# Altium schematic sheet CAN_Hat.SchDoc(CAN_Hat)
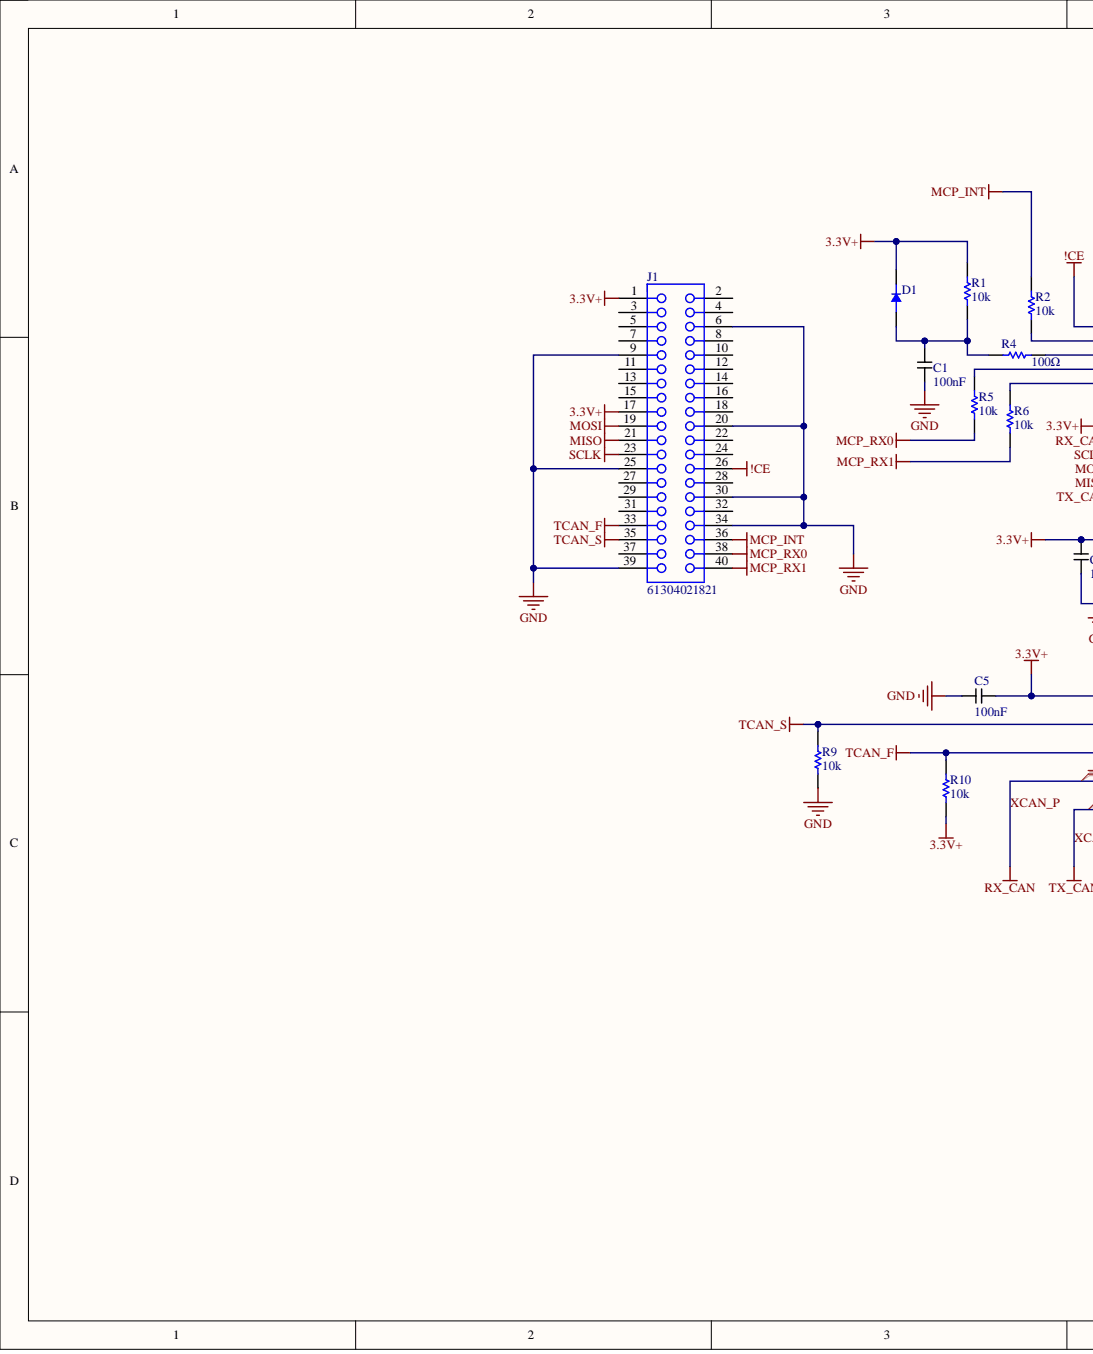
[diagram p0: CAN_Hat.SchDoc, left]
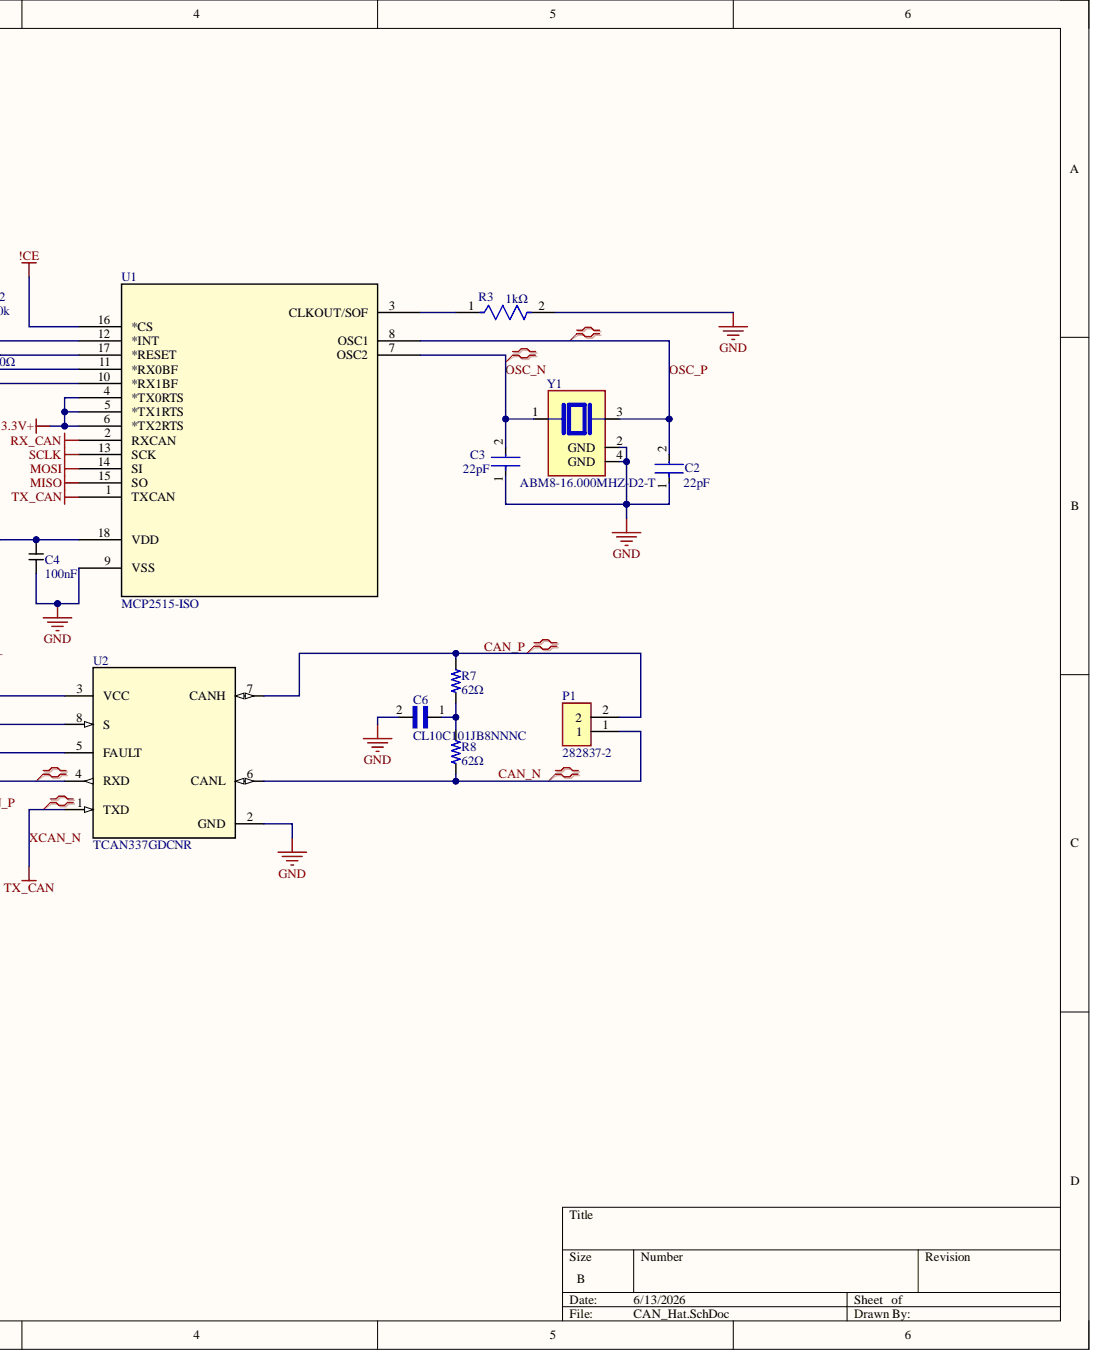
[diagram p1: CAN_Hat.SchDoc, right]

## Parts
C1  100nF
C2  value 22pF  (hidden comment: C0805C220J5GACTU)
C3  value 22pF  (hidden comment: C0805C220J5GACTU)
C4  100nF
C5  100nF
C6  CL10C101JB8NNNC
D1  1N4148W-13-F  (value not drawn)
J1  61304021821
P1  282837-2
R1  10k
R2  10k
R3  value 1kΩ  (hidden comment: ERA-3AEB102V)
R4  value 100Ω  (hidden comment: CRCW0805100RFKEA)
R5  10k
R6  10k
R7  62Ω
R8  62Ω
R9  10k
R10  10k
U1  MCP2515-ISO
U2  TCAN337GDCNR
Y1  ABM8-16.000MHZ-D2-T

## Nets
3.3V+: C4.2 C5.2 D1.2 J1.1 J1.17 R1.2 R10.1 U1.4(*TX0RTS) U1.5(*TX1RTS) U1.6(*TX2RTS) U1.18(VDD) U2.3(VCC)
!CE: J1.26 U1.16(*CS)
GND: C1.1 C2.1 C3.1 C4.1 C5.1 C6.2 J1.6 J1.9 J1.20 J1.25 J1.30 J1.34 J1.39 R3.2 R9.1 U1.9(VSS) U2.2(GND) Y1.2(GND) Y1.4(GND)
MCP_INT: J1.36 R2.1
MCP_RX0: J1.38 R5.1
MCP_RX1: J1.40 R6.1
MISO: J1.21 U1.15(SO)
MOSI: J1.19 U1.14(SI)
SCLK: J1.23 U1.13(SCK)
TCAN_F: J1.33 R10.2 U2.5(FAULT)
TCAN_S: J1.35 R9.2 U2.8(S)
CAN_N: P1.1 R8.1 U2.6(CANL)
CAN_P: P1.2 R7.2 U2.7(CANH)
OSC_N: C3.2 U1.7(OSC2) Y1.1
OSC_P: C2.2 U1.8(OSC1) Y1.3
XCAN_N: U1.1(TXCAN) U2.1(TXD)
XCAN_P: U1.2(RXCAN) U2.4(RXD)
NetC1_2: C1.2 D1.1 R1.1 R4.1
NetC6_1: C6.1 R7.1 R8.2
NetR2_2: R2.2 U1.12(*INT)
NetR3_1: R3.1 U1.3(CLKOUT/SOF)
NetR4_2: R4.2 U1.17(*RESET)
NetR5_2: R5.2 U1.11(*RX0BF)
NetR6_2: R6.2 U1.10(*RX1BF)

Not connected: J1 2 3 4 5 7 8 10 11 12 13 14 15 16 18 22 24 27 28 29 31 32 37

## Library data of the parts
C1 C4 C5 = CMP-059-000047-3: 25V 100nF X7R ±10% 0603 Multilayer Ceramic Capacitors MLCC - SMD/SMT ROHS, CC0603KRX7R8BB104; MF: Yageo; Case Code (Imperial): 0603; Case Code (Metric): 1608; Case/Package: 0603; China RoHS: Compliant; Component Kind: Standard; Component Type: Standard; Composition: Ceramic; Country of Origin: Mainland China, Taiwan; Depth: 800µm; Design Item ID: CC0603KRX7R8BB104; Dielectric: X7R; Dielectric Material: Ceramic; Export Control Classification Number (ECCN) Code: EAR99; Halogen Free: Halogen Free; Harmonized Tariff Schedule (HTS) Code: 8532.24.00.20; Height: 800µm; Introduction Date: 2004-02-24; Lead Free: Lead Free; Length: 1.6mm; Lifecycle Status: Production; Material: Ceramic; Max Operating Temperature: 125°C; Min Operating Temperature: -55°C; Mounting Technology: Surface Mount; MP: CC0603KRX7R8BB104; Number of Terminals: 2; Package: 0603 Yageo; Pin Count: 2; Pins: 2; Radiation Hardening: No; REACH SVHC: Yes; RoHS: Compliant; Schedule B: 8532240020, 8532240020; SnapEDA_Link: https://www.snapeda.com/parts/CC0603KRX7R8BB104/Yageo/view-part/?ref=snap; Source: CC0603KRX7R8BB104.SchLib; Termination: SMD/SMT; Thickness: 889µm; Tolerance: 10%; Value: 100nF; Voltage: 25V; Voltage Rating: 25V; Voltage Rating (DC): 25V; Width: 800µm; Library Name: BEV
C2 C3 = CMP-059-000096-1: SMD Multilayer Ceramic Capacitor, 22 pF, 50 V, 0805 [2012 Metric], C0G / NP0; Temperature Coefficient: 30ppm/°C; Tolerance: 5%; Voltage: 50V; Case Code (Imperial): 0805; Case/Package: 0805; China RoHS: Compliant; Component Kind: Standard; Contact Material: Tin; Contact Plating: Tin; Country of Origin: Mexico; Depth: 1.25mm; Dielectric: C0G; Dielectric Material: Ceramic; Export Control Classification Number (ECCN) Code: EAR99; Harmonized Tariff Schedule (HTS) Code: 8532.24.00.20; Height: 700µm; Introduction Date: 2008-01-10; Lead Free: Lead Free; Length: 2mm; Lifecycle Status: Production; Material: Ceramic; Max Operating Temperature: 125°C; Min Operating Temperature: -55°C; Mounting Technology: Surface Mount; Number of Terminals: 2; Packaging: Cut Tape; Pins: 2; Radiation Hardening: No; REACH SVHC: Yes; RoHS: Compliant; Schedule B: 8532240020, 8532240020; Termination: SMD/SMT; Thickness: 889µm; Voltage Rating: 50V; Voltage Rating (DC): 50V; Weight: 5.499807mg; Width: 1.25mm; Library Name: BEV
C6 = CMP-059-000039-3: 100pF Â±5% 50V Ceramic Capacitor C0G, NP0 0603 (1608 Metric); MF: Samsung; Check_prices: https://www.snapeda.com/parts/CL10C101JB8NNNC/Samsung/view-part/3597915/?ref=eda; Component Kind: Standard; Component Type: Standard; Design Item ID: CL10C101JB8NNNC; Digi-Key_Part_Number: 1276-1008-1-ND; MP: CL10C101JB8NNNC; Package: 0603; Pin Count: 2; Purchase-URL: https://pricing.snapeda.com/search/part/CL10C101JB8NNNC/?ref=eda; SnapEDA_Link: https://www.snapeda.com/parts/CL10C101JB8NNNC/Samsung/view-part/3597915/?ref=snap; Source: CL10C101JB8NNNC.SchLib; Value: 100pF; Library Name: BEV
D1 = CMP-039-000037-3: Rectifier Diode, 1 Phase, 1 Element, 0.15A, 100V V(RRM), Silicon, DO-35; Average Rectified Current: 150mA; Element Configuration: Single; Forward Current: 300mA; Forward Voltage: 715mV; Max Forward Surge Current (Ifsm): 2A; Max Junction Temperature (Tj): 150°C; Max Power Dissipation: 400mW; MF: Diodes Inc.; Number of Elements: 1; Peak Reverse Current: 1µA; Recovery Time: 4ns; Reverse Standoff Voltage: 75V; Value: 2pF; Case/Package: SOD-123-2; Check_prices: https://www.snapeda.com/parts/1N4148W-13-F/Diodes+Inc./view-part/?ref=eda; China RoHS: Compliant; Component Kind: Standard; Component Type: Standard; Design Item ID: 1N4148W-13-F; Height: 1.45mm; Lead Free: Lead Free; Length: 2.85mm; Lifecycle Status: Production; Max Operating Temperature: 150°C; Max Output current: 150mA; Max Repetitive Reverse Voltage (Vrrm): 100V; Max Reverse Voltage (DC): 100V; Max Surge Current: 2A; Min Breakdown Voltage: 100V; Min Operating Temperature: -65°C; Mounting Technology: Surface Mount; MP: 1N4148W-13-F; Number of Terminals: 2; Package: SOD-123 Fairchild Semiconductor; Peak Non-Repetitive Surge Current: 2A; Pin Count: 2; Pins: 2; Power Dissipation: 400mW; Power Rating: 400mW; Radiation Hardening: No; REACH SVHC: Yes; Reverse Recovery Time: 4ns; Reverse Voltage: 100V; RoHS: Compliant; Schedule B: 8541100070, 8541100070; SnapEDA_Link: https://www.snapeda.com/parts/1N4148W-13-F/Diodes+Inc./view-part/?ref=snap; Source: 1N4148W-13-F.SchLib; Weight: 10.007382mg; Width: 1.7mm; Library Name: BEV
J1 = CMP-133-000099-1: Header, 2.54MM Pitch, Dual Socket, Straight, 40 Pins, 3A, 500VAC, Wr-phd; Contact Resistance: 20mΩ; Gender: Female; Insulation Resistance: 1GΩ; Operating Supply Voltage: 250V; Component Kind: Standard; Contact Plating: Gold; Current Rating: 3A; Insulation Material: PBT; Lead Pitch: 2.54mm; Length: 51.3mm; Max Operating Temperature: 105°C; Min Operating Temperature: -40°C; Orientation: Vertical; Pins: 40; Pitch: 2.54mm; RoHS: Compliant; Library Name: BEV
P1 = CMP-645-000001-2: Connector, Terminal Block; Wire Receptacle; 2 Pos.; 16-30 AWG; 0.2 in. CL; Side;; Manufacturer Lifecycle Status: ACTIVE; Approvals: UL; Connector System: Wire-to-Board; Current: 13.5A; Gender: Female; Max Supply Voltage (AC): 250V; MF: TE Connectivity; Number of Circuits: 2; Number of Levels: 1; Operating Supply Voltage: 300V; Pitch: 5.08mm; Plating: Brass; Tail Length: 3.5mm; Thread Size: M3; Wire Gauge (Max): 28AWG; Wire Gauge (Min): 16AWG; Wire/Cable Gauge: 15AWG; Availability: In Stock; Check_prices: https://www.snapeda.com/parts/282837-2/TE+Connectivity+AMP+Connectors/view-part/?ref=eda; China RoHS: Non-Compliant; Color: Green; Component Kind: Standard; Component Type: Standard; Connector Type: Receptacle; Contact Material: Brass; Contact Plating: Tin; Current Rating: 13.5A; Depth: 8.2mm; Design Item ID: 282837-2; ELV: Compliant; Export Control Classification Number (ECCN) Code: EAR99; Flammability Rating: UL94 V-0; Harmonized Tariff Schedule (HTS) Code: 8536.90.40.00; Height: 10.3mm; Housing Color: Green; Housing Material: Polyamide; Introduction Date: 1997-11-01; Lead Free: Lead Free; Lifecycle Status: Production; Max Current Rating: 13.5A; Max Operating Temperature: 110°C; Max Voltage Rating (AC): 250V; Min Operating Temperature: -40°C; Mounting Technology: Screw; MP: 282837-2; Number of Contacts: 2; Number of Poles: 2; Number of Positions: 2; Number of Positions Per Level: 2; Number of Rows: 1; Orientation: Straight; Package: None; Packaging: Bulk; Pin Count: 2; Pins: 2; Price: None; Purchase-URL: https://pricing.snapeda.com/search/part/282837-2/?ref=eda; Radiation Hardening: No; REACH SVHC: No; RoHS: Compliant; SnapEDA_Link: https://www.snapeda.com/parts/282837-2/TE+Connectivity+AMP+Connectors/view-part/?ref=snap; Source: 282837-2.SchLib; Termination: Solder; Voltage: 300V; Voltage Rating: 300V; Voltage Rating (AC): 250V; Width: 11.5mm; Library Name: BEV
R1 R2 R5 R6 R9 R10 = CMP-061-000012-3: Fixed Resistor, Metal Glaze/thick Film, 0.125W, 10000ohm, 150V, 1% +/-Tol, 100ppm/Cel, Surface Mount, 0805; Power Rating: 250mW; Case/Package: 0805; Component Kind: Standard; Value: 10k; Library Name: BEV
R3 = CMP-061-000201-1: Res Thin Film 0603 1K Ohm 0.1% 0.1W ±25ppm/°C Molded SMD Punched Carrier T/R; Manufacturer Lifecycle Status: ACTIVE; Part Series: Thin Film Chip Resistors, High Reliability Type; Max Power Dissipation: 100mW; Voltage Rating: 75V; Case Code (Imperial): 0603; Case/Package: 0603; Component Kind: Standard; Composition: Thin Film; Contact Plating: Tin; Depth: 800µm; Height: 450µm; Lead Free: Lead Free; Length: 1.6mm; Lifecycle Status: Production; Max Operating Temperature: 155°C; Military Standard: Not; Min Operating Temperature: -55°C; Mounting Technology: Surface Mount; Number of Terminals: 2; Number of Terminations: 2; Packaging: Tape & Reel; Pins: 2; Power Dissipation: 100mW; Power Rating: 100mW; Radiation Hardening: No; REACH SVHC: Yes; RoHS: Compliant; Schedule B: 8533210045, 8533210045; Temperature Coefficient: 25ppm/°C; Termination: SMD/SMT; Tolerance: 0.1%; Voltage Rating (DC): 75V; Width: 800µm; Working Voltage: 75V; Library Name: BEV
R4 = CMP-061-000245-6: Res Thick Film 0805 100 Ohm 1% 0.125W(1/8W) ±100ppm/℃ Pad SMD Automotive T/R; Manufacturer Package Identifier: CRCW0805-e3; Max Power Dissipation: 125mW; Temperature Coefficient: 100ppm/°C; Voltage Rating: 150V; Case Code (Imperial): 0805; Case/Package: 0805; China RoHS: Non-Compliant; Component Kind: Standard; Composition: Thick Film; Contact Plating: Tin; Country of Origin: Israel; Depth: 1.25mm; Export Control Classification Number (ECCN) Code: EAR99; Features: Automotive AEC-Q200; Harmonized Tariff Schedule (HTS) Code: 8533.21.00.30; Height: 450µm; Introduction Date: 2005-02-16; Lead Free: Lead Free; Length: 2mm; Lifecycle Status: Production; Max Operating Temperature: 155°C; Military Standard: Not; Min Operating Temperature: -55°C; Mounting Technology: Surface Mount; Number of Terminals: 2; Number of Terminations: 2; Pins: 2; Power Dissipation: 125mW; Power Rating: 125mW; Radiation Hardening: No; REACH SVHC: Yes; RoHS: Compliant; Schedule B: 8533210045, 8533210045; Termination: SMD/SMT; Tolerance: 1%; Voltage Rating (DC): 150V; Weight: 5.499807mg; Width: 1.25mm; Working Voltage: 150V; Library Name: BEV
R7 R8 = CMP-061-000258-2: 125mW Thick Film Resistors 150V ±100ppm/℃ ±1% 62Ω 0805 Chip Resistor - Surface Mount ROHS; MF: Yageo; MP: RC0805FR-0762RL; Temperature Coefficient: 100ppm/°C; Case Code (Imperial): 0805; Case/Package: 0805; China RoHS: Non-Compliant; Component Kind: Standard; Component Type: Standard; Composition: Thick Film; Depth: 1.25mm; Design Item ID: RC0805FR-0762RL; Features: Moisture Resistant; Height: 500µm; Lead Free: Lead Free; Length: 2mm; Lifecycle Status: Production; Max Operating Temperature: 155°C; Max Power Dissipation: 125mW; Military Standard: Not; Min Operating Temperature: -55°C; Mounting Technology: Surface Mount; Number of Terminals: 2; Number of Terminations: 2; Package: 2012 Yageo; Packaging: Tape & Reel; Pin Count: 2; Power Dissipation: 125mW; Power Rating: 125mW; REACH SVHC: Yes; RoHS: Compliant; Schedule B: 8533210045, 8533210045; SnapEDA_Link: https://www.snapeda.com/parts/RC0805FR-0762RL/Yageo/view-part/?ref=snap; Source: RC0805FR-0762RL.SchLib; Termination: SMD/SMT; Tolerance: 1%; Value: 62Ω; Voltage Rating: 150V; Voltage Rating (DC): 150V; Width: 1.25mm; Working Voltage: 150V; Library Name: BEV
U1 = CMP-640-000000-1: Description; Manufacturer_Name: Microchip; Manufacturer_Part_Number: MCP2515-I/SO; Component Kind: Standard; Design Item ID: MCP2515-ISO; RefDes: RefDes; Source: MCP2515-ISO.SchLib; Type: Type; Copyright: Copyright (C) 2026 Ultra Librarian. All rights reserved.; Library Name: BEV
U2 = CMP-643-000000-2: 3.3-V CAN Transceivers with CAN FD (Flexible Data Rate) 8-SOT-23 -40 to 125; Manufacturer Lifecycle Status: ACTIVE; Manufacturer_Name: Texas Instruments; Max Junction Temperature (Tj): 150°C; Max Supply Voltage: 3.6V; Min Supply Voltage: 3V; Ambient Temperature Range High: 125°C; Case/Package: SOT-23; Component Kind: Standard; Design Item ID: TCAN337GDCNR; Height: 1.45mm; Interface: CAN; Lead Free: Lead Free; Length: 2.9mm; Lifecycle Status: Production; Manufacturer_Part_Number: TCAN337GDCNR; Max Operating Temperature: 125°C; Min Operating Temperature: -40°C; Pins: 8; RefDes: RefDes; RoHS: Compliant; Schedule B: 8542390000, 8542390000; Source: TCAN337GDCNR.SchLib; Thickness: 1.1mm; TYPE: TYPE; Width: 1.63mm; Copyright: Copyright (C) 2025 Ultra Librarian. All rights reserved.; Library Name: BEV
Y1 = CMP-650-000000-3: Crystal 16MHz ±20ppm (Tol) ±50ppm (Stability) 18pF FUND 70Ohm 4-Pin Ultra Mini-CSMD T/R; ESR: 70Ω; Frequency Tolerance: 0.002%; MF: Abracon LLC; Availability: In Stock; Check_prices: https://www.snapeda.com/parts/ABM8-16.000MHZ-D2-T/Abracon+LLC/view-part/?ref=eda; China RoHS: Compliant; Component Kind: Standard; Component Type: Standard; Country of Origin: Mainland China; Design Item ID: ABM8-16.000MHZ-D2-T; ESR (Equivalent Series Resistance): 70Ω; Frequency: 16MHz; Frequency Stability: 50ppm; Height: 800µm; Introduction Date: 2011-08-31; Lead Free: Lead Free; Length: 3.2mm; Lifecycle Status: Production; Load Capacitance: 18pF; Max Operating Temperature: 85°C; Min Operating Temperature: -40°C; Mounting Technology: Surface Mount; MP: ABM8-16.000MHZ-D2-T; Package: SMD-4 Abracon; Pin Count: 4; Price: None; Purchase-URL: https://pricing.snapeda.com/search/part/ABM8-16.000MHZ-D2-T/?ref=eda; REACH SVHC: Yes; RoHS: Compliant; SnapEDA_Link: https://www.snapeda.com/parts/ABM8-16.000MHZ-D2-T/Abracon+LLC/view-part/?ref=snap; Source: ABM8-16.000MHZ-D2-T.SchLib; Tolerance: 0.002%; Width: 2.5mm; Library Name: BEV
